# Altium schematic sheet schematic.SchDoc(schematic)
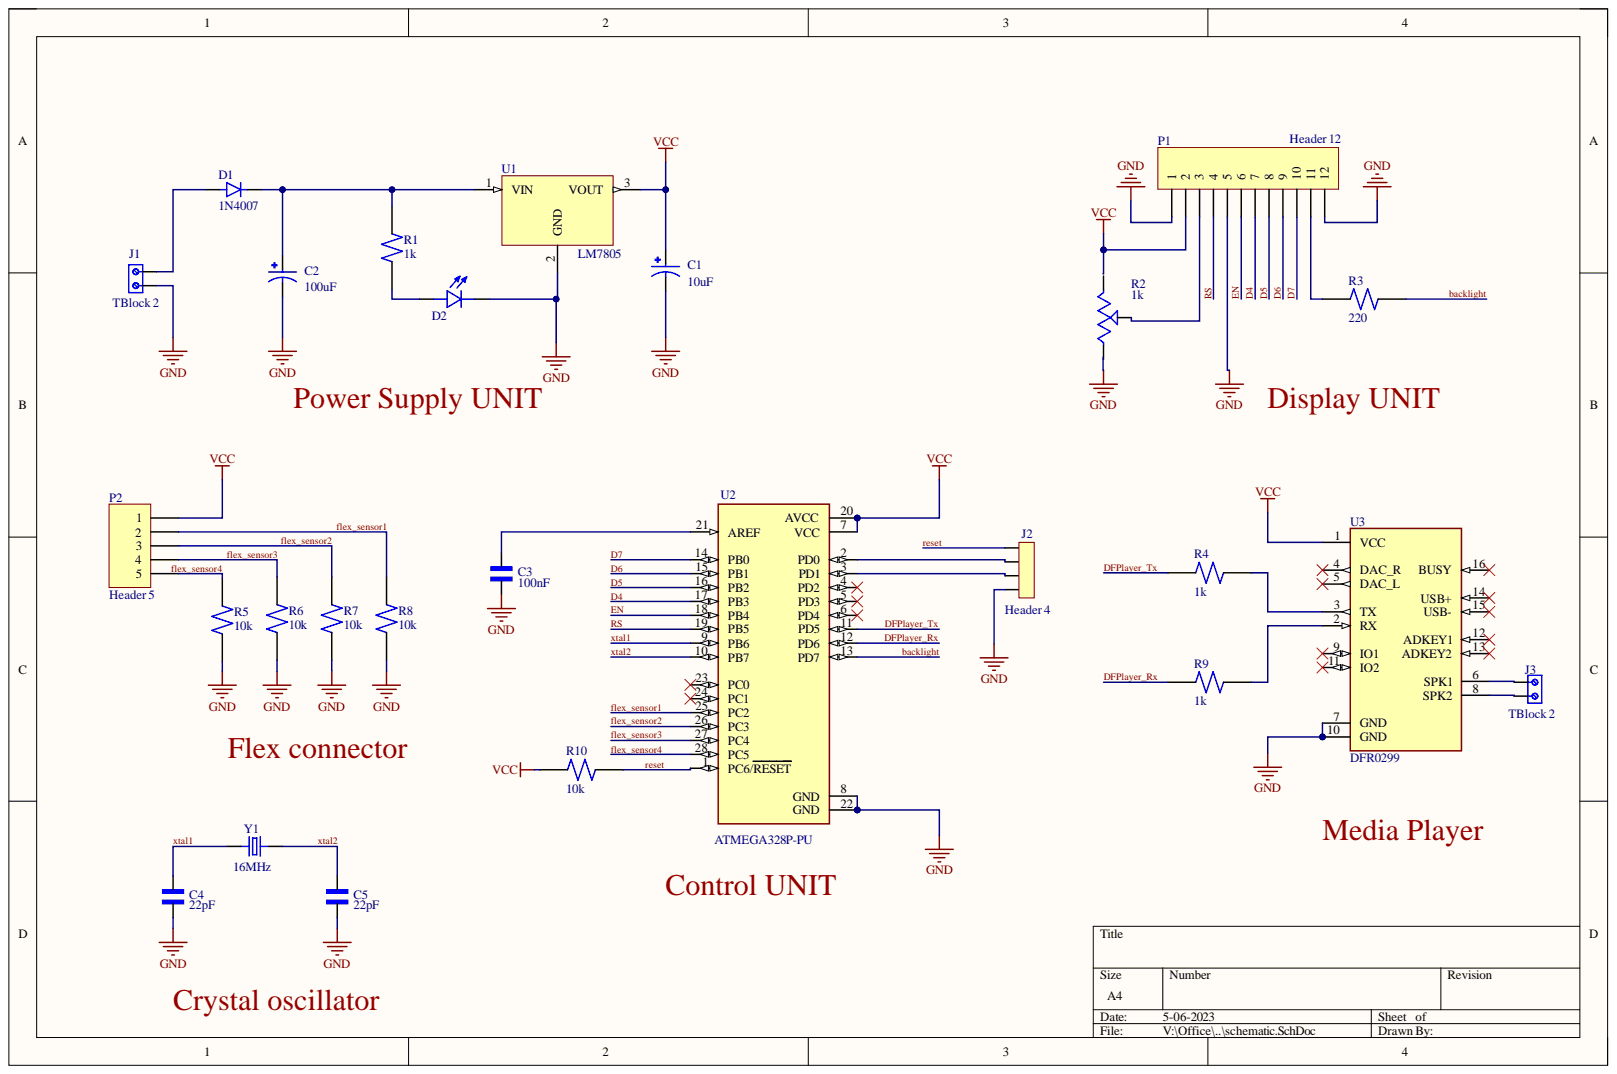
[diagram p0: schematic.SchDoc]

## Parts
C1  10uF  [CAPPRD500W50D500H1100]
C2  100uF  [CAPPRD500W50D500H1100]
C3  100nF  [CAPRB500W50L450T300H550]
C4  22pF  [CAPRB500W50L450T300H550]
C5  22pF  [CAPRB500W50L450T300H550]
D1  1N4007  [DIOAD1060W80L520D270]
D2  L08R5000Q1  [LEDRD254W57D500H1070]  (value not drawn)
J1  TBlock 2  [691111710002]
J2  Header 4  [SULLINS_PPTC041LFBN-RC]
J3  TBlock 2  [691111710002]
P1  Header 12  [HDR1X12]
P2  Header 5  [HDR1X5]
R1  1k  [RESAD1100W55L680D260]
R2  1k  [TRIM_3306F-1-105]
R3  220  [RESAD1100W55L680D260]
R4  1k  [RESAD1100W55L680D260]
R5  10k  [RESAD1100W55L680D260]
R6  10k  [RESAD1100W55L680D260]
R7  10k  [RESAD1100W55L680D260]
R8  10k  [RESAD1100W55L680D260]
R9  1k  [RESAD1100W55L680D260]
R10  10k  [RESAD1100W55L680D260]
U1  LM7805  [TO255P1020X450X1968-3]
U2  ATMEGA328P-PU  [DIP794W46P254L2967H457Q28B]
U3  DFR0299  [MODULE_DFR0299]
Y1  16MHz  [XTAL_ECS-160-20-4X]

## Nets
GND: C1.N C2.N C3.2 C4.2 C5.2 D2.C J1.1 J2.1 P1.1 P1.5 P1.12 R5.1 R6.1 R7.1 R8.1 U1.2 U2.8(GND) U2.22(GND) U3.7(GND) U3.10(GND)
VCC: C1.P P1.2 P2.1 R10.2 U1.3 U2.7(VCC) U2.20(AVCC) U3.1(VCC)
backlight: R3.1 U2.13(PD7)
D4: P1.7 U2.17(PB3)
D5: P1.8 U2.16(PB2)
D6: P1.9 U2.15(PB1)
D7: P1.10 U2.14(PB0)
DFPlayer_Rx: R9.2 U2.12(PD6)
DFPlayer_Tx: R4.2 U2.11(PD5)
EN: P1.6 U2.18(PB4)
flex_sensor1: P2.2 R8.2 U2.25(PC2)
flex_sensor2: P2.3 R7.2 U2.26(PC3)
flex_sensor3: P2.4 R6.2 U2.27(PC4)
flex_sensor4: P2.5 R5.2 U2.28(PC5)
reset: J2.4 R10.1 U2.1(PC6/RESET)
RS: P1.4 U2.19(PB5)
xtal1: C4.1 U2.9(PB6) Y1.1
xtal2: C5.1 U2.10(PB7) Y1.2
NetC2_P: C2.P D1.C R1.2 U1.1
NetC3_1: C3.1 U2.21(AREF)
NetD1_A: D1.A J1.2
NetD2_A: D2.A R1.1
NetJ2_2: J2.2 U2.3(PD1)
NetJ2_3: J2.3 U2.2(PD0)
NetJ3_1: J3.1 U3.6(SPK1)
NetJ3_2: J3.2 U3.8(SPK2)
NetP1_3: P1.3 R2.2
NetP1_11: P1.11 R3.2
NetR4_1: R4.1 U3.3(TX)
NetR9_1: R9.1 U3.2(RX)

Not connected: R2 1 3; U2 4(PD2) 5(PD3) 6(PD4) 23(PC0) 24(PC1); U3 4(DAC_R) 5(DAC_L) 9(IO1) 11(IO2) 12(ADKEY1) 13(ADKEY2) 14(USB+) 15(USB-) 16(BUSY)

## Library data of the parts
C1 C2 = Electrolytic capacitor: FS-A; MF: {'manufacturer': u'Panasonic'}; MP: EEUFS0J221B; Availability: Unavailable; Component Kind: Standard; Package: None; Price: None; Library Name: Installed library.IntLib
C3-C5 = Ceramic capacitor: 2200 pF Â±10% 250V Ceramic Capacitor X7R Radial; MF: TDK; MP: FG24X7R2E222KNT06; Check_prices: https://www.snapeda.com/parts/FG24X7R2E222KNT06/TDK/view-part/?ref=eda; Component Kind: Standard; Digi-Key_Part_Number: 445-173386-1-ND; Package: Radial TDK; Purchase-URL: https://pricing.snapeda.com/search/part/FG24X7R2E222KNT06/?ref=eda; SnapEDA_Link: https://www.snapeda.com/parts/FG24X7R2E222KNT06/TDK/view-part/?ref=snap; Library Name: Installed library.IntLib
D1 = 1N4007: Diode Switching 1KV 1A 2-Pin DO-41; Availability: Unavailable; Component Kind: Standard; Library Name: Components.IntLib; MP: 1N4007; Package: None; Price: None
D2 = LED: LED, Orange, Through Hole, T-1 3/4 (5mm), 30 mA, 2.1 V, 635 nm; MF: {'manufacturer': u'LED Technology'}; Availability: Unavailable; Component Kind: Standard; MP: L08R5000Q1; Package: None; Price: None; Library Name: Installed library.IntLib
J1 J3 = Terminal Block - 2Pins: 2 Position Wire to Board Terminal Block Horizontal with Board 0.197 _5.00mm_ Through Hole; MF: Wurth Electronics; Availability: Unavailable; Component Kind: Standard; MP: 691111710002; Package: None; Price: None; SnapEDA_Link: https://www.snapeda.com/parts/691111710002/Wurth%20Electronics%20Inc./view-part/1195092/?ref=snap; Library Name: Installed library.IntLib
J2 = Female header - 4Pins: 4 Position Header Connector Through Hole; MF: Sullins Connector; MP: PPTC041LFBN-RC; Availability: Warning; Check_prices: https://www.snapeda.com/parts/PPTC041LFBN-RC/Sullins%20Connector%20Solutions/view-part/285917/?ref=eda; Component Kind: Standard; Package: None; Price: None; Purchase-URL: https://pricing.snapeda.com/search/part/PPTC041LFBN-RC/?ref=eda; SnapEDA_Link: https://www.snapeda.com/parts/PPTC041LFBN-RC/Sullins%20Connector%20Solutions/view-part/285917/?ref=snap; Library Name: Installed library.IntLib
P1 = Header 12: Header, 12-Pin; Component Kind: Standard; LatestRevisionDate: 17-Jul-2002; LatestRevisionNote: Re-released for DXP Platform.; Library Name: Miscellaneous Connectors.IntLib; Signal Integrity: Connector; Publisher: Altium Limited
P2 = Header 5: Header, 5-Pin; Component Kind: Standard; LatestRevisionDate: 17-Jul-2002; LatestRevisionNote: Re-released for DXP Platform.; Library Name: Miscellaneous Connectors.IntLib; Signal Integrity: Connector; Publisher: Altium Limited
R1 R3-R10 = Resistor (0.25W): (MFR-25FBF52-10K) 10,2 kOhm bei 1% 0,25W, 1/4W Durchbohrlochwiderstand Axial Metal Film; MF: Yageo; Component Kind: Standard; Digi-Key_Part_Number: 10.2KXBK-ND; MP: MFR-25FBF52-10K; Package: Axial Yageo; Purchase-URL: https://pricing.snapeda.com/search/part/MFR-25FBF52-10K/?ref=eda; SnapEDA_Link: https://www.snapeda.com/parts/MFR-25FBF52-10K/Yageo/view-part/424333/?ref=snap; Library Name: Installed library.IntLib
R2 = Potentiometer1: (3306F-1-105) 1 MOhms 0.2W, 1/5W PC Pins Through Hole Trimmer Potentiometer Cermet 1 Turn Top Adjustment; MF: Bourns; Availability: Good; Check_prices: https://www.snapeda.com/parts/3306F-1-105/Bourns%20-%20J.W.%20Miller/view-part/862876/?ref=eda; Component Kind: Standard; MP: 3306F-1-105; Package: 3306F Bourns; Price: None; Purchase-URL: https://pricing.snapeda.com/search/part/3306F-1-105/?ref=eda; SnapEDA_Link: https://www.snapeda.com/parts/3306F-1-105/Bourns%20-%20J.W.%20Miller/view-part/862876/?ref=snap; Library Name: Installed library.IntLib
U1 = LM78__: MP: LM7805CT/NOPB; Availability: Unavailable; Component Kind: Standard; Library Name: Voltage regulator.IntLib; Package: None; Price: None
U2 = ATMEGA328P-PU: ATmega Series 20 MHz 32 KB Flash 2 KB SRAM 8-Bit Microcontroller - DIP-28; Availability: Unavailable; Component Kind: Standard; MP: ATMEGA328P-PU; Package: None; Price: None; Library Name: Installed library.IntLib
U3 = DFPlayer: Dfplayer - a Mini Mp3 Player; Availability: Unavailable; Component Kind: Standard; Library Name: Modules.IntLib; MP: DFR0299; Package: None; Price: None
Y1 = Crystal oscilator: CRYSTAL T/H; MF: {'manufacturer': u'ECS Inc.'}; MP: ECS-160-S-4; Availability: Unavailable; Component Kind: Standard; Package: None; Price: None; Library Name: Installed library.IntLib
